# Altium schematic sheet eGAN-FET-module_Bridge.SchDoc
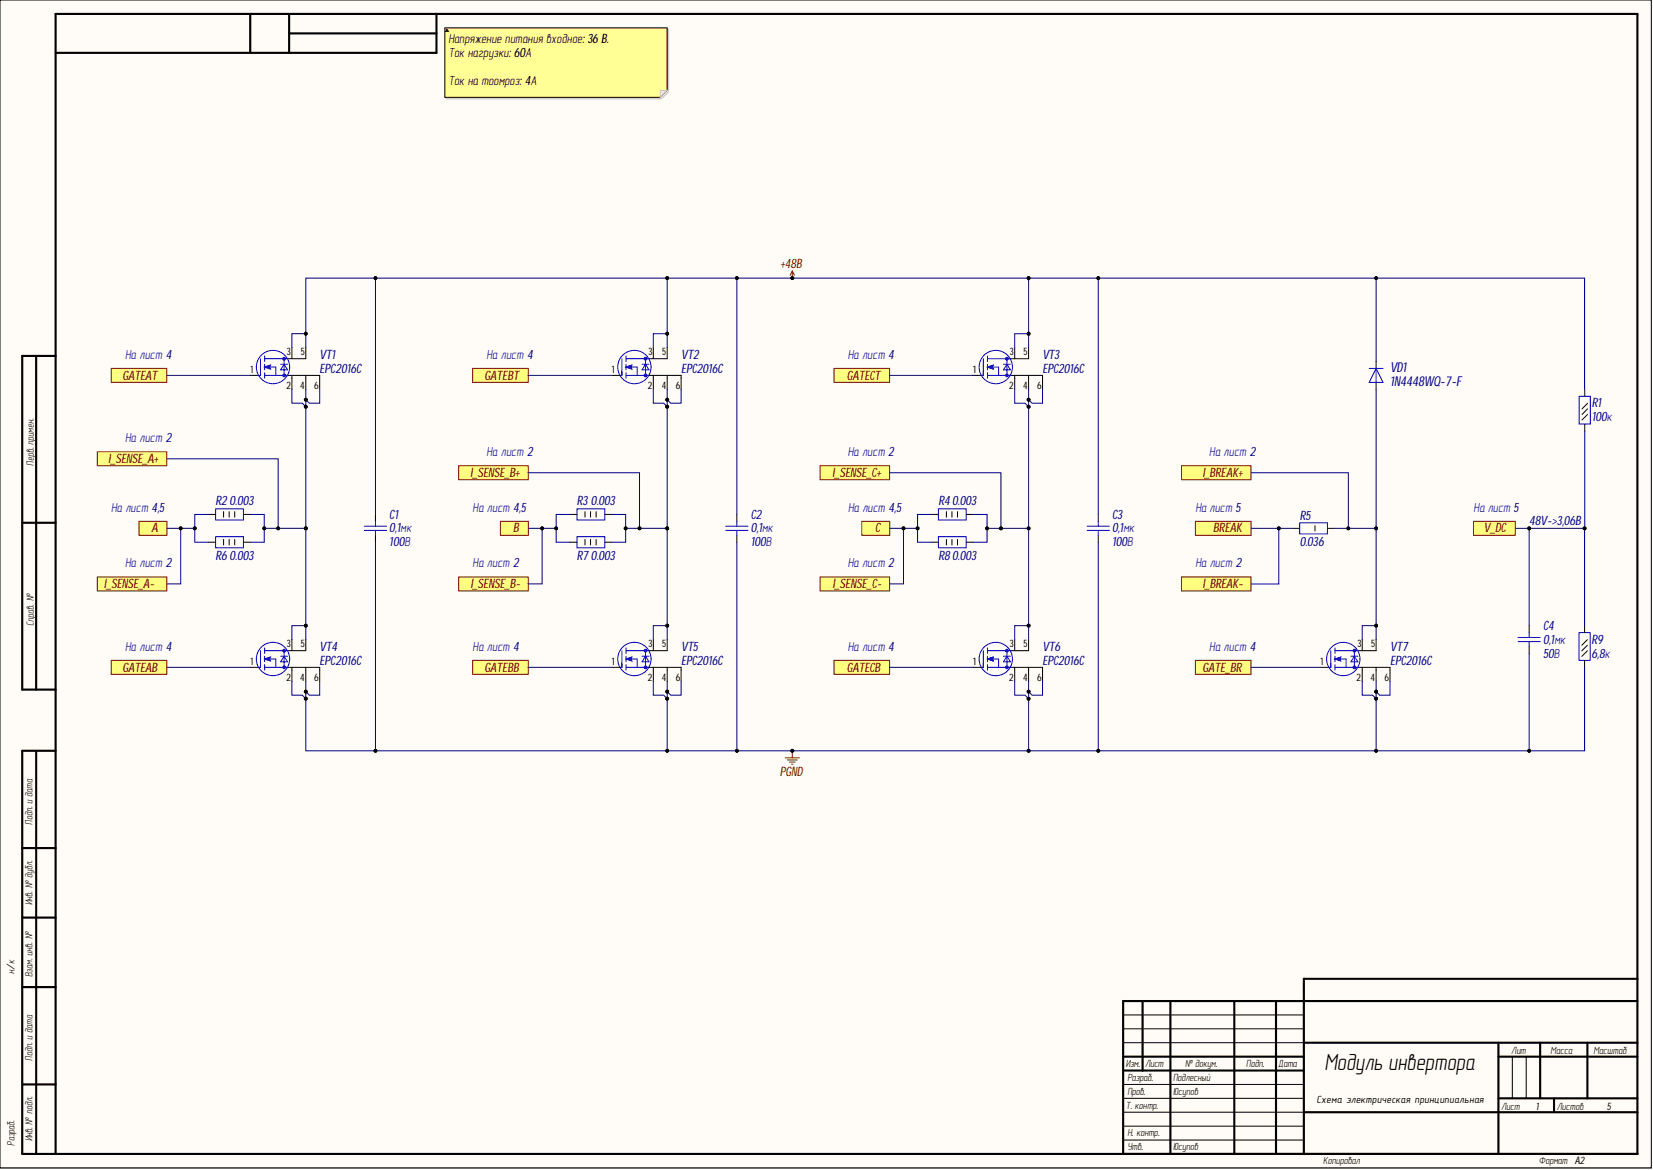
[diagram p0: eGAN-FET-module_Bridge.SchDoc]

## Parts
C1  0,1мк  Voltage: 100В  [C1206]
C2  0,1мк  Voltage: 100В  [C1206]
C3  0,1мк  Voltage: 100В  [C1206]
C4  value 0,1мк  Voltage: 50В  [C0402]  (hidden comment: Capacitor)
R1  value 100к  [R0402]  (hidden comment: Resistor_0,062Вт)
R2  0.003  [R2512]
R3  0.003  [R2512]
R4  0.003  [R2512]
R5  0.036  [R1206]
R6  0.003  [R2512]
R7  0.003  [R2512]
R8  0.003  [R2512]
R9  6,8к  [R0402]
VD1  1N4448WQ-7-F  [SOD-123]
VT1  EPC2016C  [EPC2016C]
VT2  EPC2016C  [EPC2016C]
VT3  EPC2016C  [EPC2016C]
VT4  EPC2016C  [EPC2016C]
VT5  EPC2016C  [EPC2016C]
VT6  EPC2016C  [EPC2016C]
VT7  EPC2016C  [EPC2016C]

## Ports
A, B, BREAK, C, GATE_BR, GATEAB, GATEAT, GATEBB, GATEBT, GATECB, GATECT, I_BREAK+, I_BREAK-, I_SENSE_A+, I_SENSE_A-, I_SENSE_B+, I_SENSE_B-, I_SENSE_C+, I_SENSE_C-, V_DC

## Nets
+48B: C1.2 C2.2 C3.2 VD1.1 VT1.3 VT1.5 VT2.3 VT2.5 VT3.3 VT3.5
PGND: C1.1 C2.1 C3.1 C4.2 R9.1 VT4.2 VT4.4 VT4.6 VT5.2 VT5.4 VT5.6 VT6.2 VT6.4 VT6.6 VT7.2 VT7.4 VT7.6
A: R2.1 R6.1 ; port A
B: R3.1 R7.1 ; port B
BREAK: R5.1 ; port BREAK
C: R4.1 R8.1 ; port C
GATE_BR: VT7.1 ; port GATE_BR
GATEAB: VT4.1 ; port GATEAB
GATEAT: VT1.1 ; port GATEAT
GATEBB: VT5.1 ; port GATEBB
GATEBT: VT2.1 ; port GATEBT
GATECB: VT6.1 ; port GATECB
GATECT: VT3.1 ; port GATECT
I_BREAK+: R5.2 VD1.2 VT7.3 VT7.5 ; port I_BREAK+
I_SENSE_A+: R2.2 R6.2 VT1.2 VT1.4 VT1.6 VT4.3 VT4.5 ; port I_SENSE_A+
I_SENSE_B+: R3.2 R7.2 VT2.2 VT2.4 VT2.6 VT5.3 VT5.5 ; port I_SENSE_B+
I_SENSE_C+: R4.2 R8.2 VT3.2 VT3.4 VT3.6 VT6.3 VT6.5 ; port I_SENSE_C+
V_DC: C4.1 R1.1 R9.2 ; port V_DC

Not connected: R1 2

## Library data of the parts
C1-C3 = cap286: Конденсатор; Manufacture: Murata; Part Name: Ceramic; Part Number: GRM31C5C2A104JA01L; Database Table Name: Capacitors$; TKE: NP0; Component Kind: Standard; id: cap286; Library Name: MOP44.DbLib; Value: 0,1мк
C4 = cap277: Конденсатор; Manufacture: Murata; Part Name: Ceramic; Part Number: GRM155R71H104KE14D; Database Table Name: Capacitors$; tga: 0,10%; TKE: X7R; Component Kind: Standard; id: cap277; Library Name: MOP44.DbLib
R1 = res318: Резистор; Manufacture: Yageo; Part Number: RC0402FR-07100KL; Accuracy: 0.01; Database Table Name: Resistor$; Component Kind: Standard; id: res318; Library Name: MOP44.DbLib; Part Name: Resistor; Power: 0,062Вт
R2-R4 R6-R8 = CRE2512-FZ-R003E-3: Резистор; Manufacture: Bourns; Part Name: Current Sense Resistors; Accuracy: 0.01; Database Table Name: Shunt$; id: shunt11; Power: 3Вт; Component Kind: Standard; Library Name: MOP44.DbLib; Part Number: CRE2512-FZ-R003E-3; Value: 0.003
R5 = ERJ-8CWFR036V: Резистор; Part Name: Current Sense Resistors; Accuracy: 0.01; Database Table Name: Shunt$; id: shunt12; Power: 1Вт; Component Kind: Standard; Library Name: MOP44.DbLib; Part Number: ERJ-8CWFR036V; Value: 0.036
R9 = res290: Резистор; Manufacture: Yageo; Part Name: Resistor; Part Number: RC0402FR-076K8; Accuracy: 0.01; Database Table Name: Resistor$; Power: 0,062Вт; Component Kind: Standard; id: res290; Library Name: MOP44.DbLib; Value: 6,8к
VD1 = diode45: Диод; Manufacture: Diodes Incorporated; Part Name: General Purpose Diodes; Capacitance: 4pF; Database Table Name: Diode$; Forward Current: 4A; Forward Voltage: 1,25B; Max Reverse Voltage: 100B; Number: 1; Reverse Current: 2,5uA; Reverse Recovery time: 4ns; Component Kind: Standard; id: diode45; Library Name: MOP44.DbLib; Part Number: 1N4448WQ-7-F; Temp MAX: +150; Temp MIN: -65
VT1-VT7 = mosfert39: eGaN Enhancement Mode Power Transistor; Manufacturer: EPC; Continuous drain current: 18A; Database Table Name: MOSFETTransistor$; Gate-to-source voltage: (+)6/-4; Pulsed drain current: 75A; Rds: 0,016Ohm; Structure: n-channel; Total gate charge: 4,5nC; V drain-source max: 100B; Component Kind: Standard; id: mosfert39; Library Name: MOP44.DbLib; Part Number: EPC2016C
